# Altium schematic sheet 01-M2_ZED-F9T_MODULE.SchDoc(01-M2_ZED-F9T_MODULE)
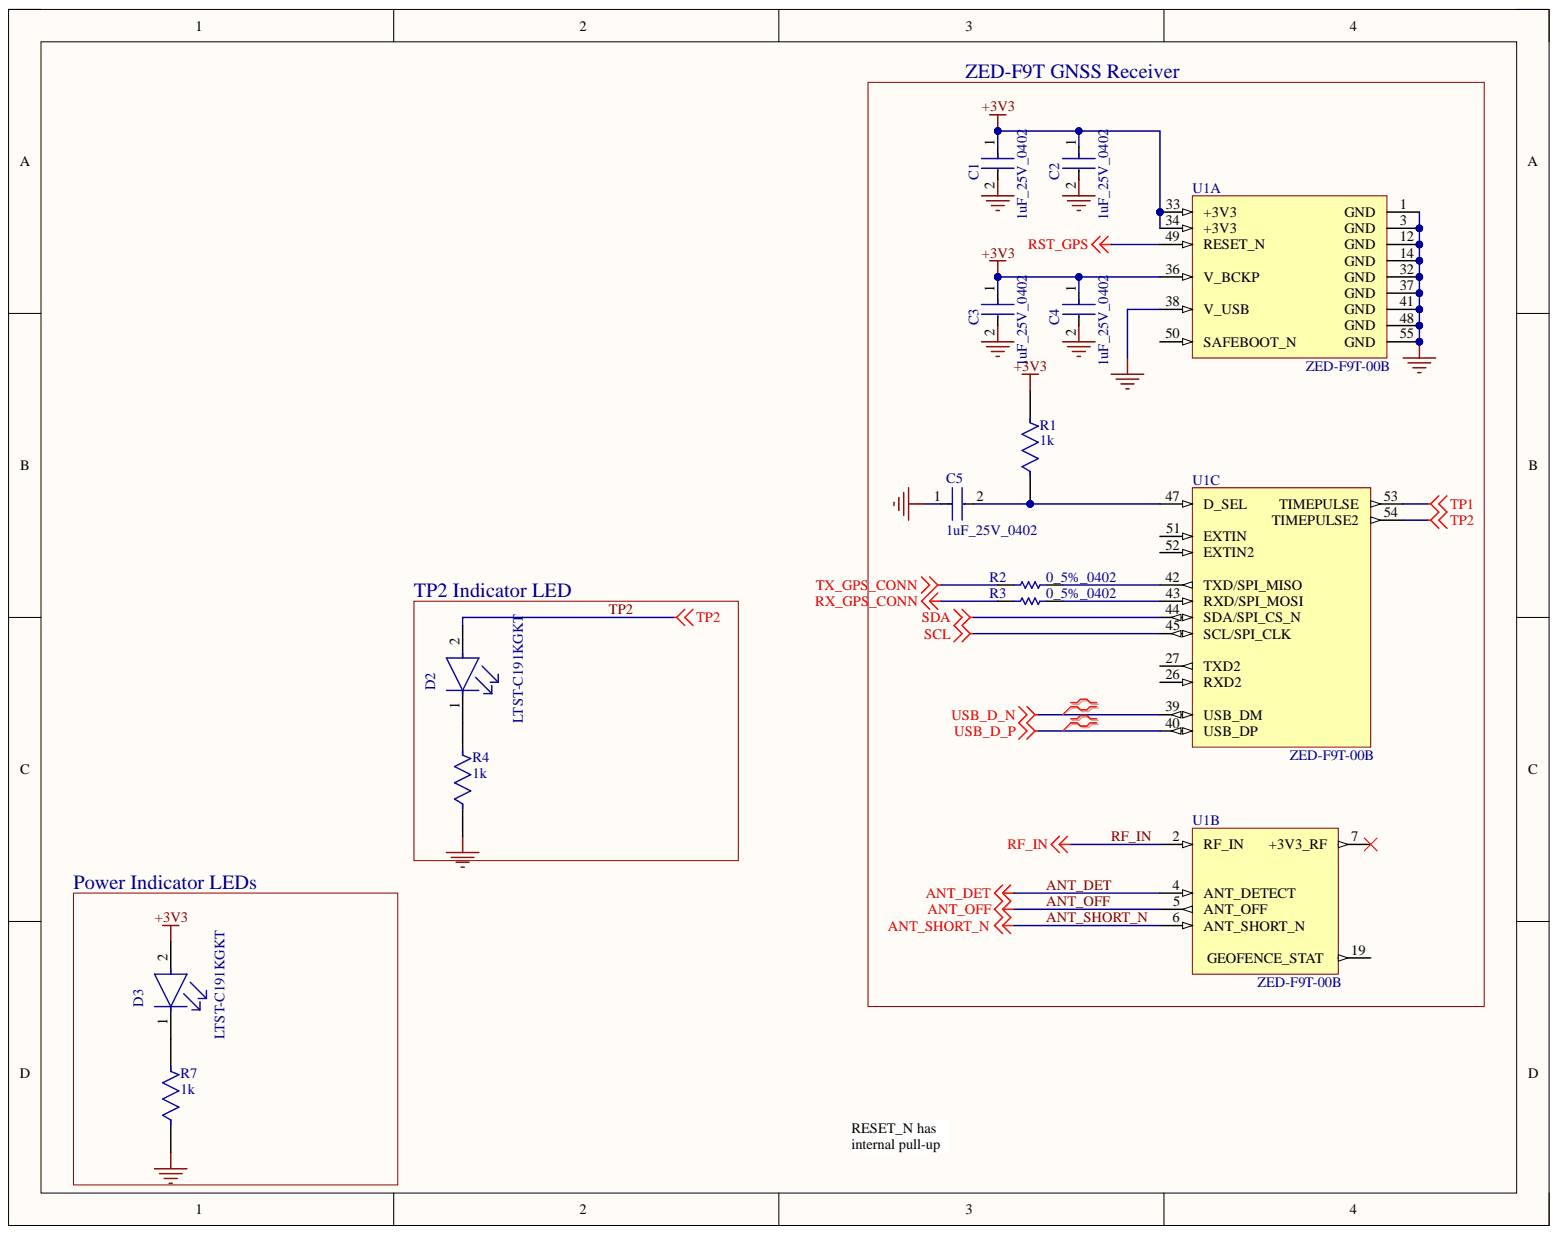
[diagram p0: 01-M2_ZED-F9T_MODULE.SchDoc]

## Parts
C1  1uF_25V_0402  [FP-CL542-IPC_C]
C2  1uF_25V_0402  [FP-CL542-IPC_C]
C3  1uF_25V_0402  [FP-CL542-IPC_C]
C4  1uF_25V_0402  [FP-CL542-IPC_C]
C5  1uF_25V_0402  [FP-CL542-IPC_C]
D2  LTST-C191KGKT  [FP-LTST-C191KGKT-MFG]
D3  LTST-C191KGKT  [FP-LTST-C191KGKT-MFG]
R1  1k  [FP-RMCF0402-MFG]
R2  0_5%_0402  [RESC1005X40X25NL05T10]
R3  0_5%_0402  [RESC1005X40X25NL05T10]
R4  1k  [FP-RMCF0402-MFG]
R7  1k  [FP-RMCF0402-MFG]
U1  ZED-F9T-00B  [GPS_ZED-F9T]  (parts A,B,C)

## Nets
+3V3: C1.1 C2.1 C3.1 C4.1 D3.2 R1.2 U1.33(+3V3) U1.34(+3V3) U1.36(V_BCKP)
GND: C1.2 C2.2 C3.2 C4.2 C5.1 R4.1 R7.1 U1.1(GND) U1.3(GND) U1.12(GND) U1.14(GND) U1.32(GND) U1.37(GND) U1.38(V_USB) U1.41(GND) U1.48(GND) U1.55(GND)
RST_GPS: U1.49(RESET_N)
RX_GPS_CONN: R3.1
SCL: U1.45(SCL/SPI_CLK)
SDA: U1.44(SDA/SPI_CS_N)
TP1: U1.53(TIMEPULSE)
TX_GPS_CONN: R2.1
USB_D_N: U1.39(USB_DM)
USB_D_P: U1.40(USB_DP)
ANT_DET: U1.4(ANT_DETECT)
ANT_OFF: U1.5(ANT_OFF)
ANT_SHORT_N: U1.6(ANT_SHORT_N)
RF_IN: U1.2(RF_IN)
TP2: D2.2 U1.54(TIMEPULSE2)
NetC5_2: C5.2 R1.1 U1.47(D_SEL)
NetD2_1: D2.1 R4.2
NetD3_1: D3.1 R7.2
NetR2_2: R2.2 U1.42(TXD/SPI_MISO)
NetR3_2: R3.2 U1.43(RXD/SPI_MOSI)

Not connected: U1 7(+3V3_RF) 19(GEOFENCE_STAT) 26(RXD2) 27(TXD2) 50(SAFEBOOT_N) 51(EXTIN) 52(EXTIN2)

## Library data of the parts
C1-C5 = CMP-13271-001051-1: Cap Ceramic 1uF 25V X5R ±10% SMD 0402 +85°C Paper T/R; Capacitance: 1uF; Case Code (Imperial): 0402; Case Code (Metric): 1005; Case/Package: 0402; Component Kind: Standard; Depth: 0.5mm; Dielectric: X5R; Height: 0.5mm; Lead Free: Lead Free; Length: 1mm; Library Name: Altium Content Vault; Material: Ceramic; Max Operating Temperature: 85°C; Min Operating Temperature: -55°C; Mount: Surface Mount; Package Quantity: 10000; Packaging: Tape and Reel; Radiation Hardening: No; Thickness: 0.022inch; Tolerance: 10%; Voltage Rating: 25V; Width: 0.02inch
D2 D3 = CMP-2000-05198-2: LED GREEN CLEAR SMD; Dominant Wavelength: 571nm; Forward Current: 30mA; Forward Voltage: 2V; Lens Color: Colorless; Lens Style: Rectangular; Luminous Intensity: 35mcd; Max Power Dissipation: 75mW; Number of Elements: 1; Reverse Voltage: 5V; Test Current: 20mA; Viewing Angle: 130°; Wavelength: 574nm; Case Code (Imperial): 0603; Case Code (Metric): 1608; Case/Package: 0603; Color: Green; Component Kind: Standard; Depth: 0.8mm; Height: 0.55mm; Illumination Color: Green; Lead Free: Lead Free; Length: 1.6mm; Lens Transparency: Clear; Library Name: Altium Content Vault; Max Operating Temperature: 85°C; Min Operating Temperature: -55°C; Mount: Surface Mount; Number of LEDs: 1; Number of Pins: 2; Package Quantity: 1; Packaging: Tape and Reel; Power Dissipation: 75mW; Power Rating: 75mW; Radiation Hardening: No; Width: 0.8mm
R1 R4 R7 = CMP-26527-000026-1: 1kΩ ±1% 0.063W 0402 Thick Film Chip Resistor AEC-Q200 compliant; Max Power Dissipation: 63mW; Resistance: 1kR; Temperature Coefficient: 100ppm/°C; Case Code (Imperial): 0402; Case Code (Metric): 1005; Case/Package: 0402; Component Kind: Standard; Construction: Rectangular; Contact Plating: Tin; Depth: 0.5mm; Features: Automotive AEC-Q200; Height: 0.016inch; Lead Free: Lead Free; Length: 1mm; Library Name: Altium Content Vault; Max Operating Temperature: 155°C; Military Standard: Not; Min Operating Temperature: -55°C; Mount: Surface Mount; Number of Terminations: 2; Packaging: Tape and Reel; Power Rating: 63mW; Radiation Hardening: No; Resistor Type: Thick Film; RoHS Compliant: Yes; Series: RMCF; Size Code: 0402; Tolerance: 1%; Width: 0.02inch
R2 R3 = CMP-2002-06457-1: Manufacturer: Panasonic; Part Number: ERJ-U020R00X; Component Kind: Standard; Library Name: Altium Content Vault
U1 = GPS_ZED-F9T: Multi-band GNSS Receiver with Nanosecond-level Timing Accuracy; Manufacturer: u-blox; Interface: I2C,SPI,USB,UART; Sensitivity: -166dBm; Case/Package: SMD/SMT; Category: RF Receivers, Transceivers; Component Kind: Standard; ComponentLink1Description: https://www.u-blox.com/sites/default/files/ZED-F9T_ProductSummary_%28UBX-18011626%29.pdf?src-supplier=Digi-Key; ComponentLink1URL: https://www.u-blox.com/sites/default/files/ZED-F9T_ProductSummary_%28UBX-18011626%29.pdf?src-supplier=Digi-Key; Library Name: GNSS_Receiver_Library.IntLib; Manufacturer Part Number: ZED-F9T-00B; Max Operating Temperature: 85°C; Min Operating Temperature: -40°C; Packaging: Tape and Reel; Pricing 1: 1=199.00000, 10=198.80000, 50=180.89020 (USD); RoHS: Yes; Stock 1: 826; Supplier 1: Digi-Key; Supplier Part Number 1: 672-1197-1-ND
